# Altium schematic sheet Schematics.SchDoc
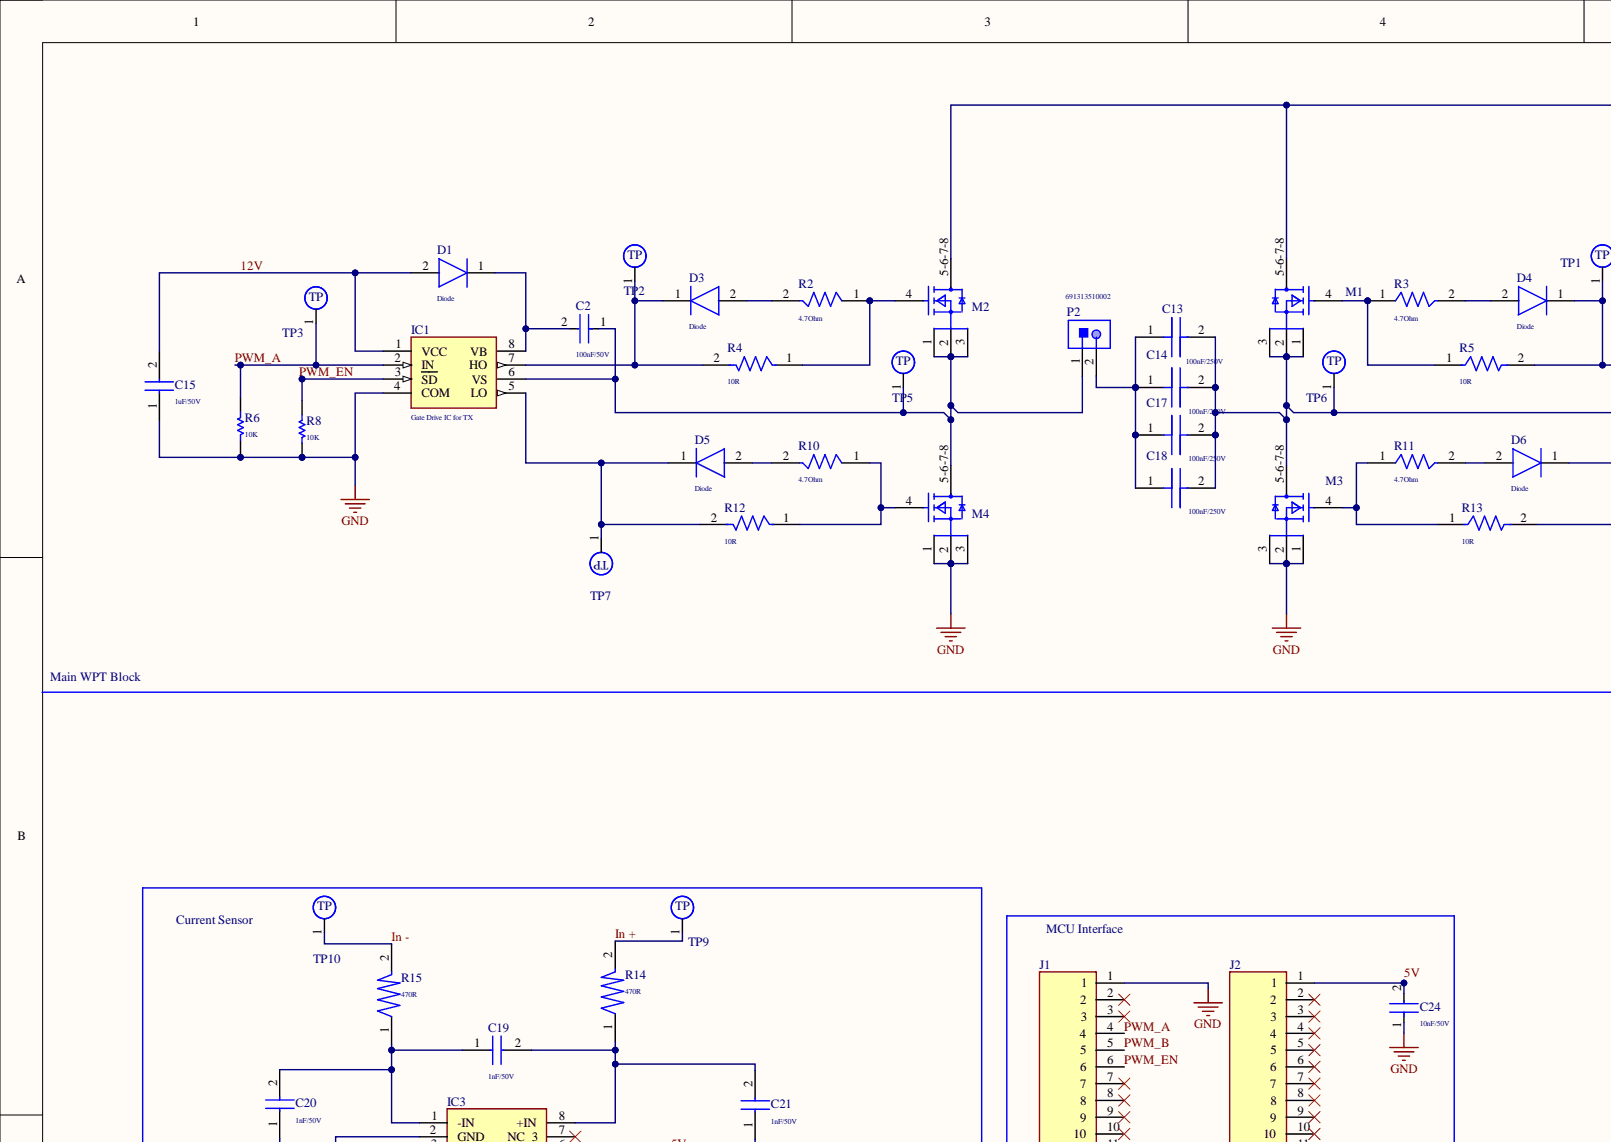
[diagram p0: Schematics.SchDoc, top left]
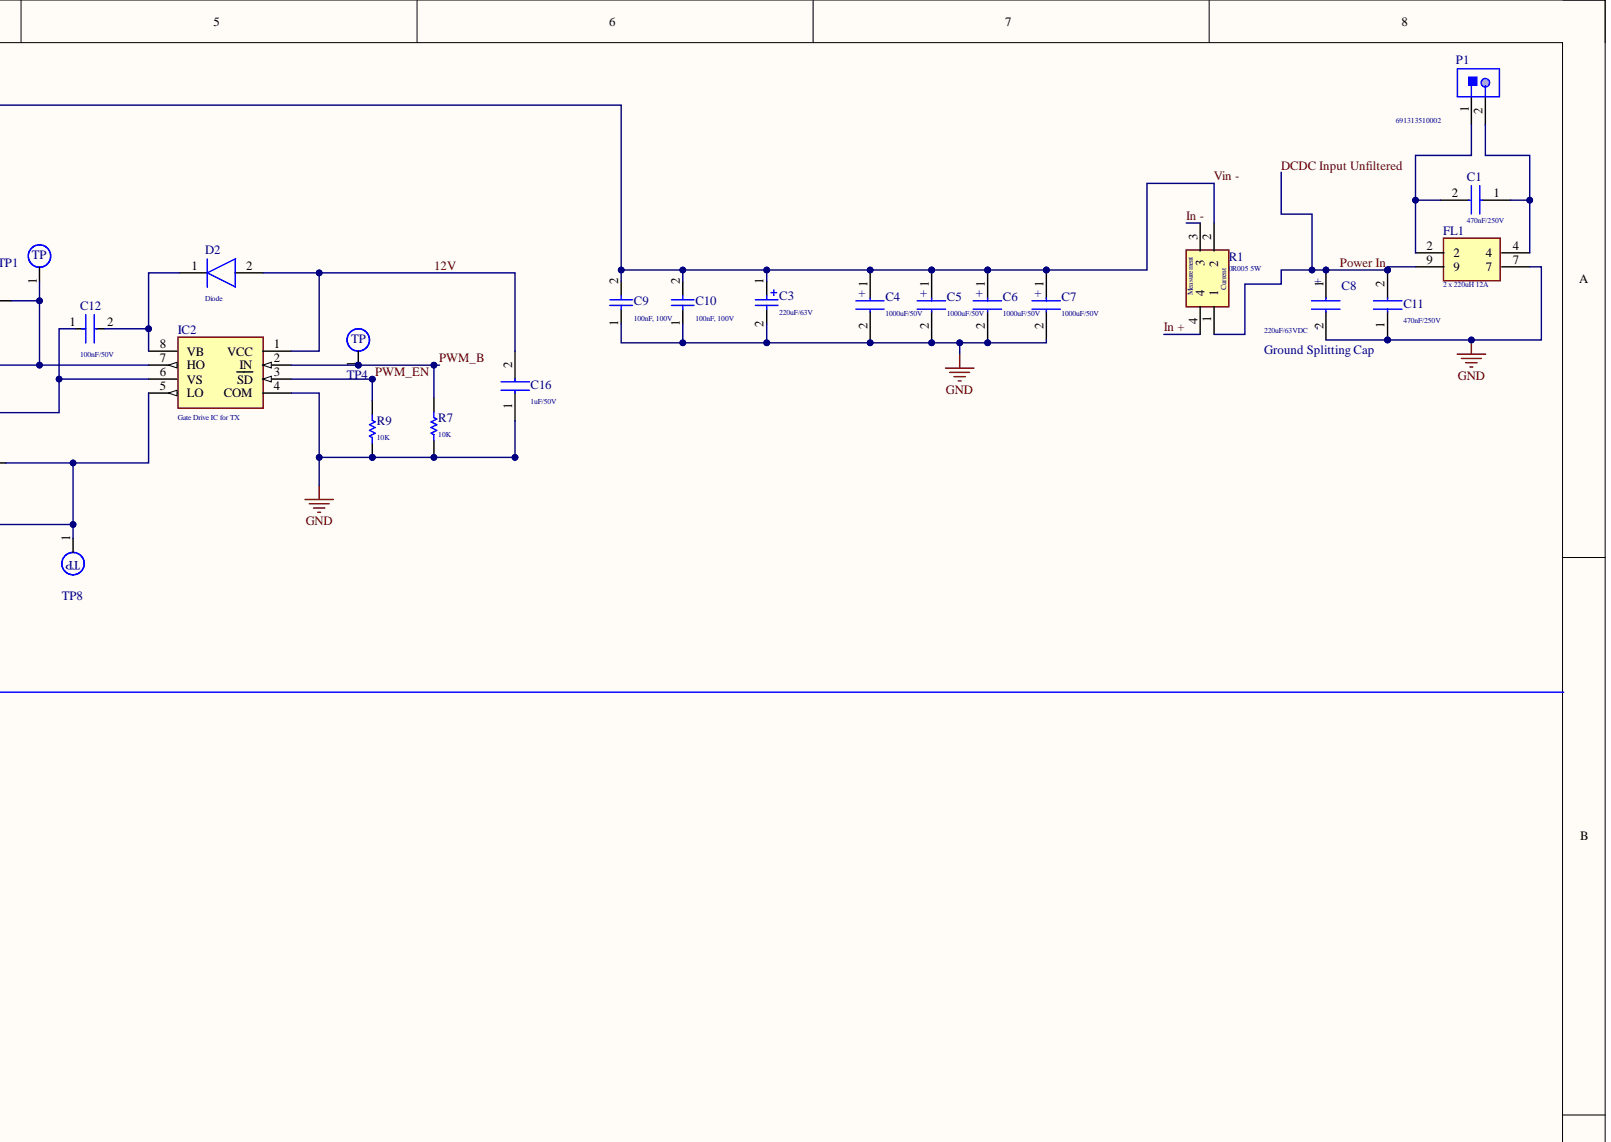
[diagram p1: Schematics.SchDoc, top right]
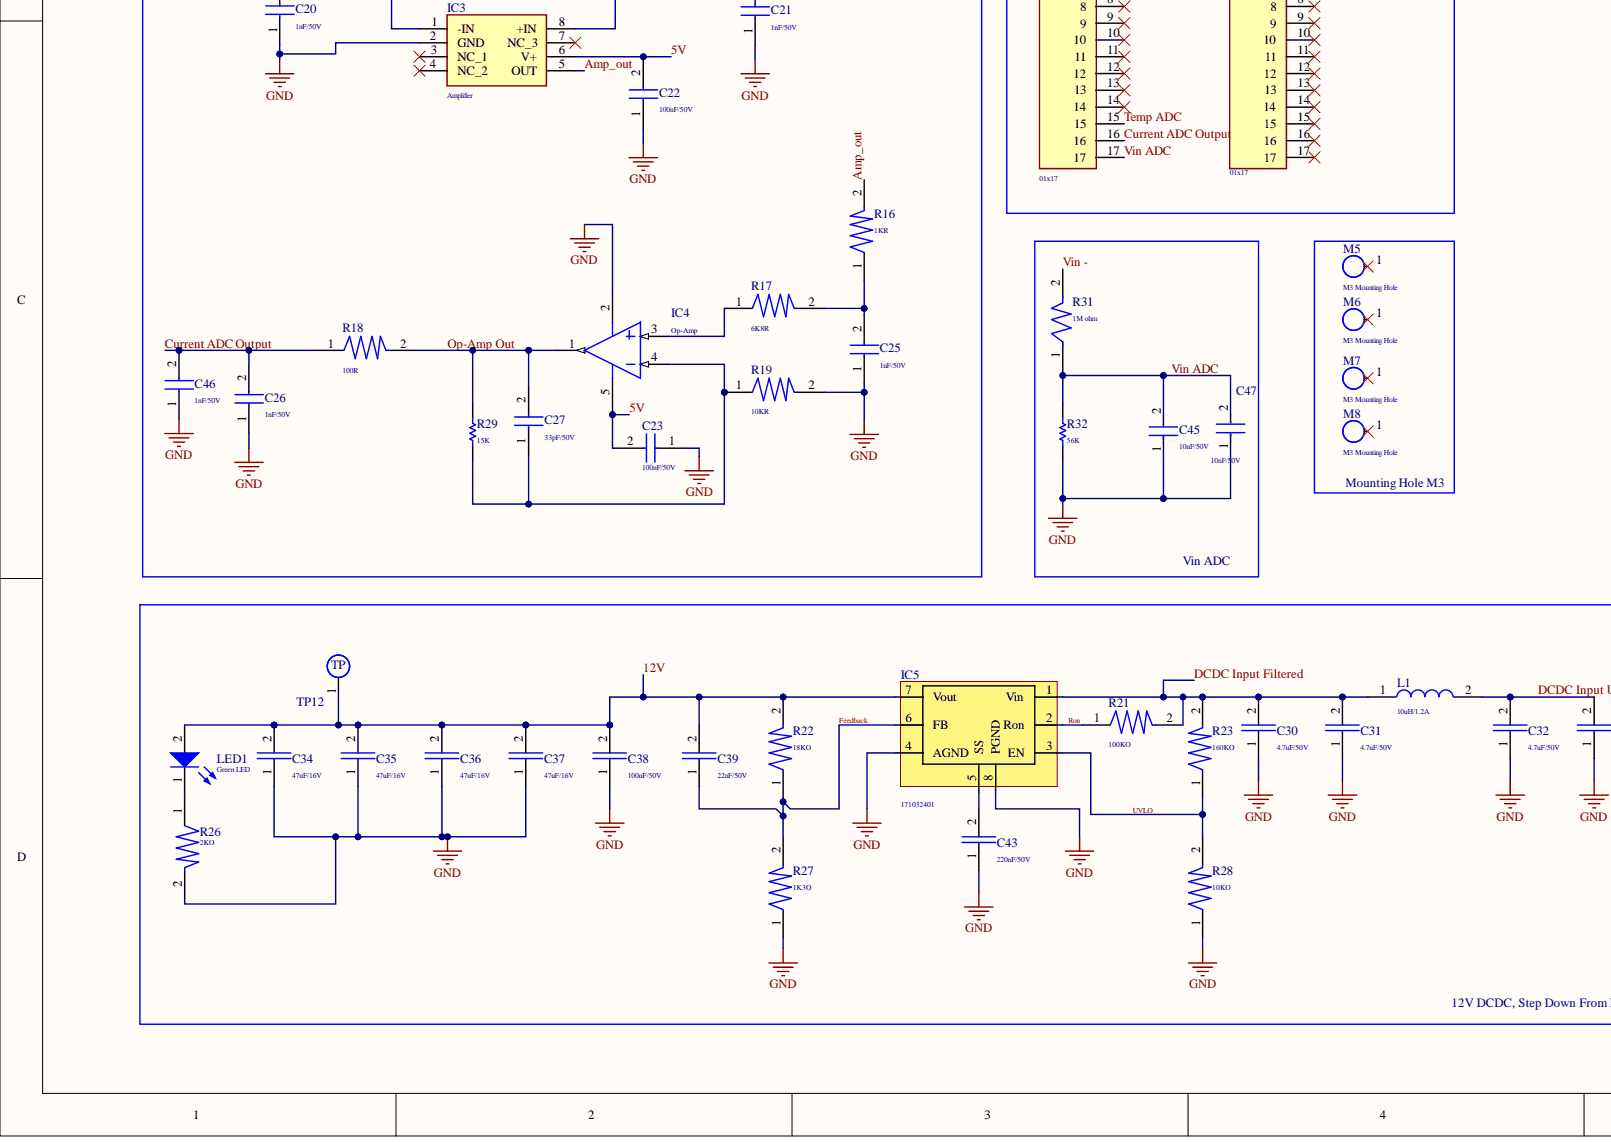
[diagram p2: Schematics.SchDoc, bottom left]
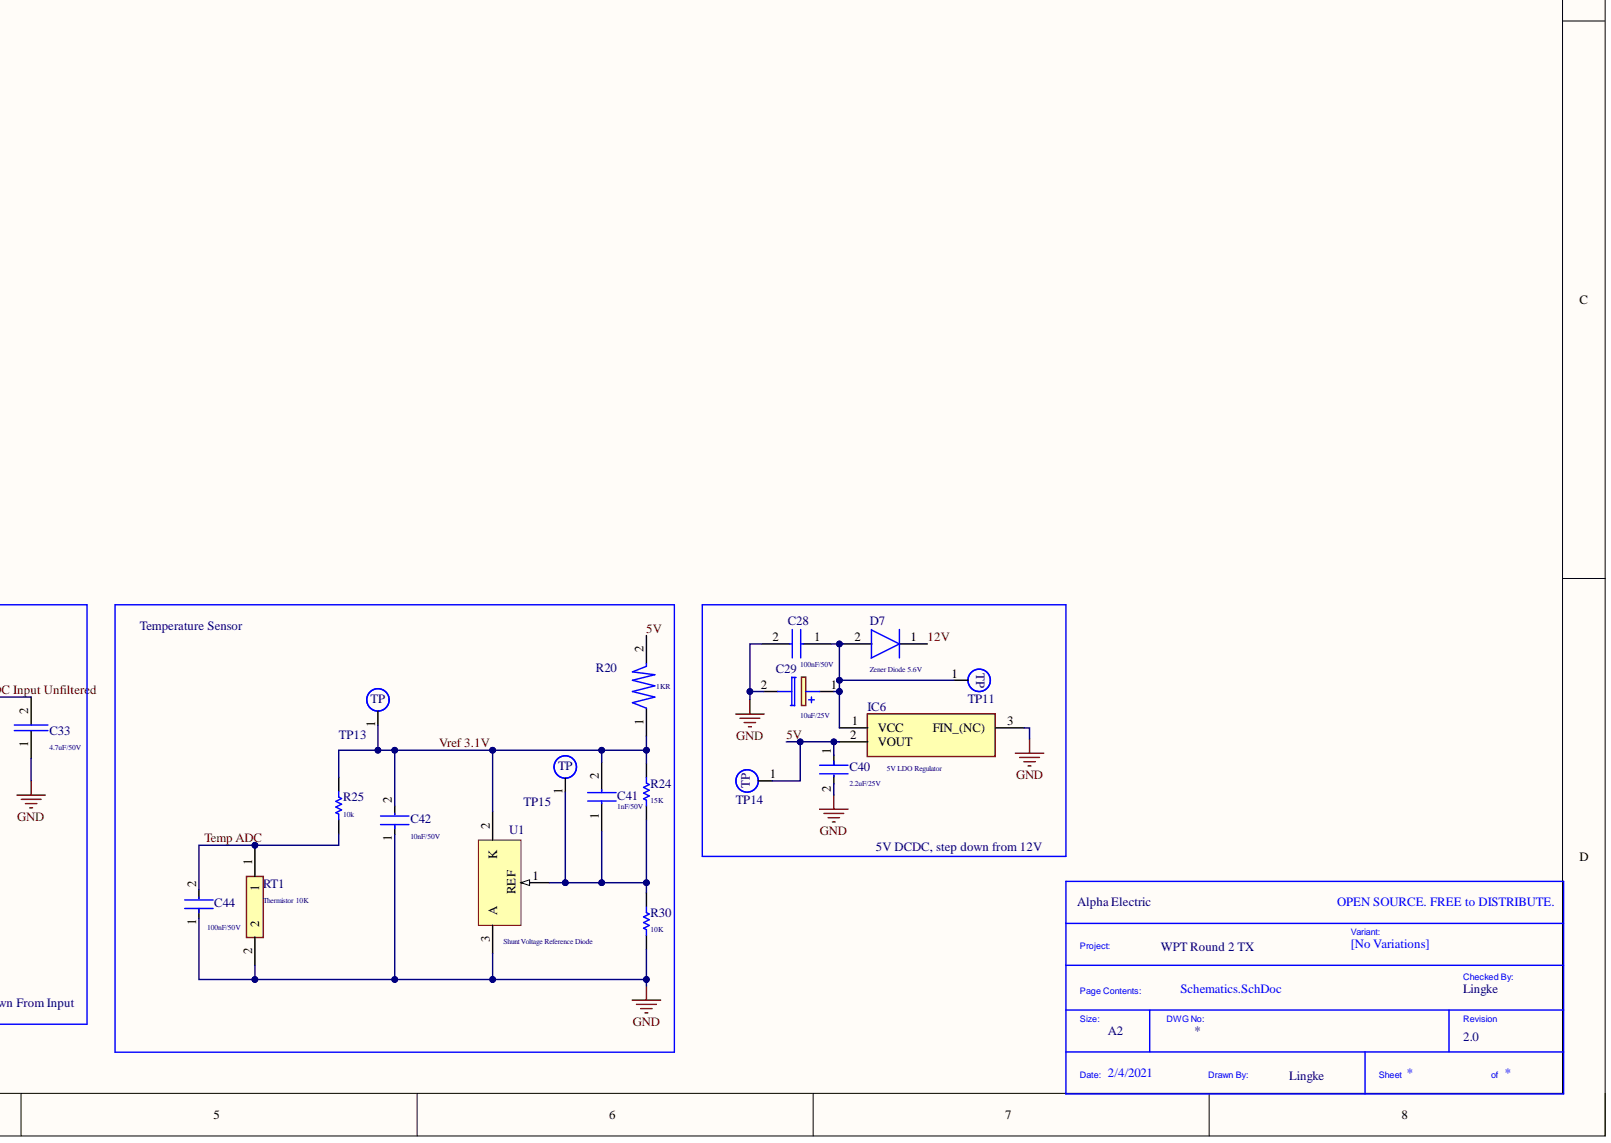
[diagram p3: Schematics.SchDoc, bottom right]

## Parts
*  Checked By: Lingke  Company: Alpha Electric  Project Name: WPT Round 2 TX  Revision: 2.0  Size: A2  (hidden comment: Title_Block)  (no BOM)
C1  470nF/250V  [890324025039CS]
C2  100nF/50V  [0805 Capacitor]
C3  220uF/63V  [WCAP-ATUL_D10H16]
C4  1000uF/50V  [CAPPRD500W62D1300H2650]
C5  1000uF/50V  [CAPPRD500W62D1300H2650]
C6  1000uF/50V  [CAPPRD500W62D1300H2650]
C7  1000uF/50V  [CAPPRD500W62D1300H2650]
C8  220uF/63VDC  [CAPPRD500W62D1000H2650]
C9  100nF, 100V  [WCAP-CSGP_0805_H=1.25mm]
C10  100nF, 100V  [WCAP-CSGP_0805_H=1.25mm]
C11  470nF/250V  [890324025039CS]
C12  100nF/50V  [0805 Capacitor]
C13  100nF/250V  [CAPC4532X220N]
C14  100nF/250V  [CAPC4532X220N]
C15  1uF/50V  [CAPC2012X135N]
C16  1uF/50V  [CAPC2012X135N]
C17  100nF/250V  [CAPC4532X220N]
C18  100nF/250V  [CAPC4532X220N]
C19  1nF/50V  [CAPC2012X90N]
C20  1nF/50V  [CAPC2012X90N]
C21  1nF/50V  [CAPC2012X90N]
C22  100nF/50V  [CAPC2012X90N]
C23  100nF/50V  [CAPC2012X90N]
C24  10nF/50V  [0805 Capacitor]
C25  1nF/50V  [CAPC2012X90N]
C26  1nF/50V  [CAPC2012X90N]
C27  33pF/50V  [CAPC2012X90N]
C28  100nF/50V  [CAPC2012X90N]
C29  10uF/25V  [CAPAE530X550N]
C30  4.7uF/50V  [CAPC3225X280N]
C31  4.7uF/50V  [CAPC3225X280N]
C32  4.7uF/50V  [CAPC3225X280N]
C33  4.7uF/50V  [CAPC3225X280N]
C34  47uF/16V  [CAPC3225X280N]
C35  47uF/16V  [CAPC3225X280N]
C36  47uF/16V  [CAPC3225X280N]
C37  47uF/16V  [CAPC3225X280N]
C38  100nF/50V  [CAPC3216X90N]
C39  22nF/50V  [CAPC2012X90N]
C40  2.2uF/25V  [0805 Capacitor]
C41  1nF/50V  [CAPC2012X90N]
C42  10nF/50V  [0805 Capacitor]
C43  220nF/50V  [CAPC2012X90N]
C44  100nF/50V  [0805 Capacitor]
C45  10nF/50V  [0805 Capacitor]
C46  1nF/50V  [CAPC2012X90N]
C47  10nF/50V  [0805 Capacitor]
D1  Diode  [SOD3716X135N]
D2  Diode  [SOD3716X135N]
D3  Diode  [SOD3716X135N]
D4  Diode  [SOD3716X135N]
D5  Diode  [SOD3716X135N]
D6  Diode  [SOD3716X135N]
D7  Zener Diode 5.6V  [ZMM5232B]
FL1  2 x 220uH 12A  [744844221]
IC1  Gate Drive IC for TX  [SOIC127P600X175-8N]
IC2  Gate Drive IC for TX  [SOIC127P600X175-8N]
IC3  Amplifier  [SOIC127P600X175-8N]
IC4  Op-Amp  [ADI-RJ-5_N]
IC5  171032401  [7 PIN PFM]
IC6  5V LDO Regulator  [ROHM_TO-252-3]
J1  01x17  [RHDR17W76P0X254_1X17_4318X254X826P]
J2  01x17  [RHDR17W76P0X254_1X17_4318X254X826P]
L1  10uH/1.2A  [WE-MAPI_3020]
LED1  Green LED  Color: Green  [0805_A]
M1  Mosfet  [FP-PG-TDSON-8-MFG]  (value not drawn)
M2  Mosfet  [FP-PG-TDSON-8-MFG]  (value not drawn)
M3  Mosfet  [FP-PG-TDSON-8-MFG]  (value not drawn)
M4  Mosfet  [FP-PG-TDSON-8-MFG]  (value not drawn)
M5  M3 Mounting Hole  [M3 Hole]
M6  M3 Mounting Hole  [M3 Hole]
M7  M3 Mounting Hole  [M3 Hole]
M8  M3 Mounting Hole  [M3 Hole]
P1  691313510002  [691313510002]
P2  691313510002  [691313510002]
R1  0R005 5W  [FC4L110R005FER]
R2  4.7Ohm  [FP-CRCW1206-e3-IPC_A]
R3  4.7Ohm  [FP-CRCW1206-e3-IPC_A]
R4  10R  [FP-CRCW1206-e3-IPC_A]
R5  10R  [FP-CRCW1206-e3-IPC_A]
R6  10K  [0805 Resistor]
R7  10K  [0805 Resistor]
R8  10K  [0805 Resistor]
R9  10K  [0805 Resistor]
R10  4.7Ohm  [FP-CRCW1206-e3-IPC_A]
R11  4.7Ohm  [FP-CRCW1206-e3-IPC_A]
R12  10R  [FP-CRCW1206-e3-IPC_A]
R13  10R  [FP-CRCW1206-e3-IPC_A]
R14  470R  [FP-CRCW0805-e3-MFG]
R15  470R  [FP-CRCW0805-e3-MFG]
R16  1KR  [FP-CRCW0805-e3-MFG]
R17  6K8R  [FP-CRCW0805-e3-MFG]
R18  100R  [FP-CRCW0805-e3-MFG]
R19  10KR  [FP-CRCW0805-e3-MFG]
R20  1KR  [FP-CRCW0805-e3-MFG]
R21  100KO  [FP-CRCW0805-e3-MFG]
R22  18KO  [FP-CRCW0805-e3-MFG]
R23  160KO  [FP-CRCW0805-e3-MFG]
R24  15K  [0805 Resistor]
R25  10k  [0805 Resistor]
R26  2KO  [FP-CRCW0805-e3-MFG]
R27  1K3O  [FP-CRCW0805-e3-MFG]
R28  10KO  [FP-CRCW0805-e3-MFG]
R29  15K  [0805 Resistor]
R30  10K  [0805 Resistor]
R31  1M ohm  [FP-CRCW0805-e3-MFG]
R32  56K  [0805 Resistor]
RT1  Thermistor 10K  [THRMC2012X95N]
TP1  TP  [Test Point]  (no BOM)
TP2  TP  [Test Point]  (no BOM)
TP3  TP  [Test Point]  (no BOM)
TP4  TP  [Test Point]  (no BOM)
TP5  TP  [Test Point]  (no BOM)
TP6  TP  [Test Point]  (no BOM)
TP7  TP  [Test Point]  (no BOM)
TP8  TP  [Test Point]  (no BOM)
TP9  TP  [Test Point]  (no BOM)
TP10  TP  [Test Point]  (no BOM)
TP11  TP  [Test Point]  (no BOM)
TP12  TP  [Test Point]  (no BOM)
TP13  TP  [Test Point]  (no BOM)
TP14  TP  [Test Point]  (no BOM)
TP15  TP  [Test Point]  (no BOM)
U1  Shunt Voltage Reference Diode  [SOT23-3_N]

## Nets
GND: C3.2 C4.2 C5.2 C6.2 C7.2 C8.2 C9.1 C10.1 C11.1 C15.1 C16.1 C20.1 C21.1 C22.1 C23.1 C24.1 C25.1 C26.1 C28.2 C29.2 C30.1 C31.1 C32.1 C33.1 C34.1 C35.1 C36.1 C37.1 C38.1 C40.2 C42.1 C43.1 C44.1 C45.1 C46.1 C47.1 FL1.7 IC1.4(COM) IC2.4(COM) IC3.2(GND) IC4.2 IC5.4(AGND) IC5.8(PGND) IC6.3 J1.1 M3.1 M3.2 M3.3 M4.1 M4.2 M4.3 R6.1 R7.1 R8.1 R9.1 R19.2 R26.2 R27.1 R28.1 R30.1 R32.1 RT1.2 U1.3
5V: C22.2 C23.2 C24.2 C40.1 IC3.6(V+) IC4.5 IC6.2 J2.1 R20.2 TP14.1
12V: C15.2 C16.2 C34.2 C35.2 C36.2 C37.2 C38.2 C39.2 D1.2 D2.2 D7.1 IC1.1(VCC) IC2.1(VCC) IC5.7(Vout) LED1.2 R22.2 TP12.1
Amp_out: IC3.5(OUT) R16.2
Current ADC Output: C26.2 C46.2 J1.16 R18.1
DCDC Input Filtered: C30.2 C31.2 IC5.1(Vin) L1.1 R21.2 R23.2
DCDC Input Unfiltered: C8.1 C11.2 C32.2 C33.2 FL1.9 L1.2 R1.1
Feedback: C39.1 IC5.6(FB) R22.1 R27.2
In +: R1.4 R14.2 TP9.1
In -: R1.3 R15.2 TP10.1
Op-Amp Out: C27.2 IC4.1 R18.2 R29.2
PWM_A: IC1.2(IN) J1.4 R6.2 TP3.1
PWM_B: IC2.2(IN) J1.5 R7.2 TP4.1
PWM_EN: IC1.3(SD) IC2.3(SD) J1.6 R8.2 R9.2
Ron: IC5.2(Ron) R21.1
Temp ADC: C44.2 J1.15 R25.1 RT1.1
UVLO: IC5.3(EN) R23.1 R28.2
Vin -: C3.1 C4.1 C5.1 C6.1 C7.1 C9.2 C10.2 M1.5-6-7-8 M2.5-6-7-8 R1.2 R31.2
Vin ADC: C45.2 C47.2 J1.17 R31.1 R32.2
Vref 3.1V: C41.2 C42.2 R20.1 R24.2 R25.2 TP13.1 U1.2
NetC1_1: C1.1 FL1.4 P1.2
NetC1_2: C1.2 FL1.2 P1.1
NetC2_1: C2.1 IC1.6(VS) M2.1 M2.2 M2.3 M4.5-6-7-8 P2.1 TP5.1
NetC2_2: C2.2 D1.1 IC1.8(VB)
NetC12_1: C12.1 C13.2 C14.2 C17.2 C18.2 IC2.6(VS) M1.1 M1.2 M1.3 M3.5-6-7-8 TP6.1
NetC12_2: C12.2 D2.1 IC2.8(VB)
NetC13_1: C13.1 C14.1 C17.1 C18.1 P2.2
NetC19_1: C19.1 C20.2 IC3.1(-IN) R15.1
NetC19_2: C19.2 C21.2 IC3.8(+IN) R14.1
NetC25_2: C25.2 R16.1 R17.2
NetC27_1: C27.1 IC4.4 R19.1 R29.1
NetC28_1: C28.1 C29.1 D7.2 IC6.1 TP11.1
NetC41_1: C41.1 R24.1 R30.2 TP15.1 U1.1
NetC43_2: C43.2 IC5.5(SS)
NetD3_1: D3.1 IC1.7(HO) R4.2 TP2.1
NetD3_2: D3.2 R2.2
NetD4_1: D4.1 IC2.7(HO) R5.2 TP1.1
NetD4_2: D4.2 R3.2
NetD5_1: D5.1 IC1.5(LO) R12.2 TP7.1
NetD5_2: D5.2 R10.2
NetD6_1: D6.1 IC2.5(LO) R13.2 TP8.1
NetD6_2: D6.2 R11.2
NetIC4_3: IC4.3 R17.1
NetLED1_1: LED1.1 R26.1
NetM1_4: M1.4 R3.1 R5.1
NetM2_4: M2.4 R2.1 R4.1
NetM3_4: M3.4 R11.1 R13.1
NetM4_4: M4.4 R10.1 R12.1

Not connected: IC3 3(NC_1) 4(NC_2) 7(NC_3); J1 2 3 7 8 9 10 11 12 13 14; J2 2 3 4 5 6 7 8 9 10 11 12 13 14 15 16 17; M5 1; M6 1; M7 1; M8 1

## Library data of the parts
* = Title_Block: Standard Title Block.; Library Name: Symbol Library.SchLib; Drawn By: Lingke
C1 C11 = 890324025039CS: Safety Capacitor, 470nF/250V; Manufacturer_Name: Wurth Elektronik; Component Kind: Standard; Height: 14.5mm; Library Name: Symbol Library.SchLib; Manufacturer_Part_Number: 890324025039CS
C2 C12 C44 = 885012207098: Component Kind: Standard; Description: 100nF/50V; Library Name: Symbol Library.SchLib; Supplier 1: Mouser; Supplier Part Number 1: 710-885012207098
C3 = 860020775019: WCAP-ATUL Aluminum Electrolytic Capacitor, Radial, THT, D10xH16mm, 220uF/63V; Manufacturer: Wurth Elektronik; Manufacturer Part Number: 860040881014; Capacitance: 220uF; Capacitance Tolerance: +/-20%; Dissipation Factor: 9%; Endurance: 10000h; Leakage Current: 820uA; Rated Voltage: 63VDC; Ripple Current: 375mA; Technical Reference: ATDL410821M100DSPS1K000; Component Kind: Standard; ComponentLink1Description: Manufacturer; ComponentLink1URL: http://www.we-online.de; ComponentLink2Description: Datasheet; ComponentLink2URL: https://www.we-online.com/catalog/datasheet/860040881014.pdf; Diameter: 18mm; Height: 41mm; Library Name: Symbol Library.SchLib; Mounting Technology: THT; Operating Temperature: -40 to +105degC; Packaging: Ammo Pack; Published Date: October 2020; Supplier 1: Mouser; Supplier Part Number 1: 710-860020775019
C4-C7 = ESH108M050AL4AA: Capacitor 1000uF; Component Kind: Standard; Library Name: Symbol Library.SchLib; Supplier 1: Mouser; Supplier Part Number 1: 80-ESH108M050AL4AA
C8 = 860040775010: Polarised Cap, 220uF/63VDC; Manufacturer_Name: Wurth Elektronik; Component Kind: Standard; Datasheet Link: https://www.mouser.de/datasheet/2/445/860040775010-1725627.pdf; Height: 26.5mm; Library Name: Symbol Library.SchLib; Manufacturer_Part_Number: 860040775010
C9 C10 = 885012207128: WCAP-CSGP Multilayer Ceramic Chip Capacitor, General Purpose, size 0805, X7R, 100nF, 100V; Manufacturer: Wurth Elektronik; Capacitance Tolerance: +/-10%; Dissipation Factor: #NAME?; Isolation Resistance: >=1GOhm; Rated Voltage: 100VDC; Technical Reference: X7R0805104K100DFCT10000; Capacitance: 100nF; Ceramic Type: X7R; Component Kind: Standard; ComponentLink1Description: Manufacturer; ComponentLink1URL: http://www.we-online.de; ComponentLink2Description: Datasheet; ComponentLink2URL: https://www.we-online.com/catalog/datasheet/885012207128.pdf; Height: 1.25mm; Library Name: Symbol Library.SchLib; Manufacturer Part Number: 885012207128; Mounting Technology: SMT; Operating Temperature: -55 to +125degC; Packaging: Tape and Reel; Published Date: May 2020; Size: 0805
C13 C14 C17 C18 = C1812C104JAGACAUTO: Manufacturer_Name: Kemet; Component Kind: Standard; Datasheet Link: https://api.kemet.com/component-edge/download/datasheet/C1812C104JAGACAUTO.pdf; Description: 100nF/250V; Height: 2.2mm; Library Name: Symbol Library.SchLib; Manufacturer_Part_Number: C1812C104JAGACAUTO; Supplier 1: Mouser; Supplier Part Number 1: 80-C1812C104JAGAUTO
C15 C16 = 885012207103: Capacitor 1uF/50V; Manufacturer_Name: Wurth Elektronik; Component Kind: Standard; Datasheet Link: https://katalog.we-online.com/pbs/datasheet/885012207103.pdf; Height: 1.35mm; Library Name: Symbol Library.SchLib; Manufacturer_Part_Number: 885012207103; Supplier 1: Mouser; Supplier Part Number 1: 710-885012207103
C19-C21 C25 C26 C41 C46 = 885012207086: Manufacturer_Name: Wurth Elektronik; Component Kind: Standard; Datasheet Link: https://katalog.we-online.com/pbs/datasheet/885012207086.pdf; Description: 1nF/50V; Height: 0.9mm; Library Name: Symbol Library.SchLib; Manufacturer_Part_Number: 885012207086; Supplier 1: Mouser; Supplier Part Number 1: 710-885012207086
C22 C23 C28 = 885012207098: Manufacturer_Name: Wurth Elektronik; Component Kind: Standard; Description: 100nF/50V; Height: 0.9mm; Library Name: Symbol Library.SchLib; Supplier 1: Mouser; Supplier Part Number 1: 710-885012207098
C24 C42 C45 C47 = 885012207092: Component Kind: Standard; Description: 10nF/50V; Library Name: Symbol Library.SchLib; Supplier 1: Mouser; Supplier Part Number 1: 710-885012207092
C27 = C0805C330J5GACTU: Capacitor 33pF/50V; Manufacturer_Name: Kemet; Component Kind: Standard; Library Name: Symbol Library.SchLib; Manufacturer_Part_Number: C0805C330J5GACTU; Supplier 1: Mouser; Supplier Part Number 1: 80-C0805C330J5G
C29 = 865060440001: Capacitor Polarised 10uF/25V; Manufacturer_Name: Wurth Elektronik; Component Kind: Standard; Datasheet Link: http://katalog.we-online.com/pbs/datasheet/865060440001.pdf; Height: 5.5mm; Library Name: Symbol Library.SchLib; Manufacturer_Part_Number: 865060440001
C30-C33 = 885012209048: Capacitor 4.7uF/50V; Manufacturer_Name: Wurth Elektronik; Component Kind: Standard; Datasheet Link: https://katalog.we-online.com/pbs/datasheet/885012209048.pdf; Height: 2.8mm; Library Name: Symbol Library.SchLib; Manufacturer_Part_Number: 885012209048; Supplier 1: Mouser; Supplier Part Number 1: 710-885012209048
C34-C37 = EMK325ABJ476MMHP: Capacitor 47uF/16V; Component Kind: Standard; Library Name: Symbol Library.SchLib; Supplier 1: Mouser; Supplier Part Number 1: 963-EMK325ABJ476MMHP
C38 = 885012208087: Capacitor 100nF/50V; Manufacturer_Name: Wurth Elektronik; Component Kind: Standard; Datasheet Link: https://componentsearchengine.com//885012208087.pdf; Height: 0.9mm; Library Name: Symbol Library.SchLib; Manufacturer_Part_Number: 885012208087; Mouser2 Part Number: 710-885012208087; Mouser2 Price/Stock: https://www.mouser.com/Search/Refine.aspx?Keyword=710-885012208087; Supplier 1: Mouser; Supplier Part Number 1: 710-885012208087
C39 = 885012207094: Capacitor 22nF/50V; Manufacturer_Name: Wurth Elektronik; Component Kind: Standard; Datasheet Link: https://componentsearchengine.com//885012207094.pdf; Height: 0.9mm; Library Name: Symbol Library.SchLib; Manufacturer_Part_Number: 885012207094; Mouser2 Part Number: 710-885012207094; Mouser2 Price/Stock: https://www.mouser.com/Search/Refine.aspx?Keyword=710-885012207094; Supplier 1: Mouser; Supplier Part Number 1: 710-885012207094
C40 = 885012207079: Capacitance: 2.2; Dielectric: X5R; Voltage Rating (DC): 25V; Case Code (Imperial): 0805; Case Code (Metric): 2012; Case/Package: 0805; Component Kind: Standard; Description: 2.2uF/25V; Library Name: Symbol Library.SchLib; Max Operating Temperature: 125°C; Package Quantity: 2000; Supplier 1: Mouser; Supplier Part Number 1: 710-885012207079
C43 = 885012207100: Capacitor 220nF / 50V; Component Kind: Standard; Library Name: Symbol Library.SchLib; Supplier 1: Mouser; Supplier Part Number 1: 710-885012207100
D1-D6 = 1N4148W-E3-08: Manufacturer_Name: Vishay; Component Kind: Standard; Datasheet Link: https://componentsearchengine.com//1N4148W-E3-08.pdf; Description: Diode; Height: 1.35mm; Library Name: Symbol Library.SchLib; Manufacturer_Part_Number: 1N4148W-E3-08; Mouser2 Part Number: 78-1N4148W-E3-08; Mouser2 Price/Stock: https://www.mouser.com/Search/Refine.aspx?Keyword=78-1N4148W-E3-08; Supplier 1: Digi-Key; Supplier Part Number 1: 1N4148W-E3-08CT-ND
D7 = ZMM5232B: Zener Diode 5.6V 0.5W; Manufacturer_Name: Rectron; Component Kind: Standard; Datasheet Link: https://componentsearchengine.com/Datasheets/1/ZMM5232B.pdf; Height: 1.5mm; Library Name: Symbol Library.SchLib; Manufacturer_Part_Number: ZMM5232B; Supplier 1: Mouser; Supplier Part Number 1: 583-ZMM5232B
FL1 = 744844221: Common Mode Choke 220uH 12A; Manufacturer_Name: Wurth Elektronik; Component Kind: Standard; Height: 33mm; Library Name: Symbol Library.SchLib; Manufacturer_Part_Number: 744844221
IC1 IC2 = IRS2008SPBF: Gate Drive IC; Manufacturer_Name: Infineon; Component Kind: Standard; Height: 1.75mm; Library Name: Symbol Library.SchLib; Manufacturer_Part_Number: IRS2008SPBF; Supplier 1: Mouser; Supplier Part Number 1: 726-IRS2008SPBF
IC3 = AD8215YRZ: Integrated Circuit Amplifier; Manufacturer_Name: Analog Devices; Component Kind: Standard; Datasheet Link: http://www.analog.com/static/imported-files/data_sheets/AD8215.pdf; Height: 1.75mm; Library Name: Symbol Library.SchLib; Manufacturer_Part_Number: AD8215YRZ; Supplier 1: Mouser; Supplier Part Number 1: 584-AD8215YRZ
IC4 = AD8031ARTZ-REEL7: Single Channel RRIO Operational Amplifier, 80 MHz BW, 35 V/us SR, Industrial, 5-pin SOT23 (RJ-5), Reel; Generic Part Number: AD8031; Manufacturer: Analog Devices; Amplifiers Per Package: 1; Input Bias Current: 450nA; Input Offset Voltage: 1mV; Iq/Amp: 900uA; Slew Rate: 35V/us; Small Signal Bandwidth: 80MHz; V Span Max: 12V; V Span Min: 2.7V; Code_JEDEC: MO-178-AA; Component Kind: Standard; ComponentLink1Description: Manufacturer URL; ComponentLink1URL: http://www.analog.com/en/index.html; ComponentLink2Description: Datasheet; ComponentLink2URL: http://www.analog.com/static/imported-files/data_sheets/AD8031_8032.pdf; ComponentLink3Description: Package Specification; ComponentLink3URL: http://www.analog.com/static/imported-files/packages/PKG_PDF/SOT-23(RJ)/RJ_5.pdf; ComponentLink4Description: Reference Circuit; ComponentLink4URL: http://www.analog.com/en/all-operational-amplifiers-op-amps/operational-amplifiers-op-amps/ad8031/products/product.html#product-recommendations; DatasheetVersion: Rev. D; Library Name: Symbol Library.SchLib; Mounting Technology: Surface Mount; PackageDescription: 5-Pin Small Outline Transistor Package, Body 2.9 x 1.6 mm, Pitch 0.95 mm; PackageReference: RJ-5; PackageVersion: 121608-A; Packing: Reel; PartNumber: AD8031ARTZ-REEL7; RevisionNote: Reference Circuit URL fixed; Supplier 1: Mouser; Supplier Part Number 1: 584-AD8031ARTZ-R7
IC5 = 171032401: MagI³C-VDRM Variable Step Down Regulator Module WE-VDRM , Ui=6-42V; Manufacturer: Wuerth Elektronik; Ui: 6-42 V; Component Kind: Standard; ComponentLink1Description: Manufacturer URL; ComponentLink1URL: http://www.we-online.com; ComponentLink2Description: Datasheet; ComponentLink2URL: http://katalog-live.we-online.de/pm/datasheet/171032401.pdf; Library Name: Symbol Library.SchLib; PackageReference: 7 PIN PFM; PartNumber: 171032401; Supplier 1: Mouser; Supplier Part Number 1: 710-171032401
IC6 = BD450M5FP-CE2: Manufacturer_Name: ROHM Semiconductor; Component Kind: Standard; Datasheet Link: https://componentsearchengine.com//BD450M5FP-CE2.pdf; Description: 5V LDO Regulator; Library Name: Symbol Library.SchLib; Manufacturer_Part_Number: BD450M5FP-CE2; Mouser2 Part Number: 755-BD450M5FP-CE2; Mouser2 Price/Stock: https://www.mouser.com/Search/Refine.aspx?Keyword=755-BD450M5FP-CE2
J1 J2 = 929850-01-17-RA: Connector 2.54mm; Manufacturer_Name: 3M; Component Kind: Standard; Datasheet Link: http://multimedia.3m.com/mws/media/22500O/3mtm-boardmount-skt-100-100x-100strt-sldr-tails-ts0815.pdf; Height: 8.26mm; Library Name: Symbol Library.SchLib; Manufacturer_Part_Number: 929850-01-17-RA; Supplier 1: Mouser; Supplier Part Number 1: 517-929850-01-17-RA
L1 = 74438336100: Inductor; Manufacturer_Name: Wurth Elektronik; Component Kind: Standard; Datasheet Link: http://katalog.we-online.de/pbs/datasheet/74438336100.pdf; Library Name: Symbol Library.SchLib; Manufacturer_Part_Number: 74438336100; Supplier 1: Mouser; Supplier Part Number 1: 710-74438336100
LED1 = 150080GS75000: SMD mono-color Chip LED, WL-SMCW, Green; Manufacturer: Wuerth Elektronik; Component Kind: Standard; ComponentLink1Description: Manufacturer URL; ComponentLink1URL: http://www.we-online.com; ComponentLink2Description: Datasheet; ComponentLink2URL: http://katalog.we-online.com/led/datasheet/150080GS75000.pdf; Library Name: Symbol Library.SchLib; PackageReference: 0805_A; PartNumber: 150080GS75000; Supplier 1: Mouser; Supplier Part Number 1: 710-150080GS75000
M1-M4 = BSC040N10NS5ATMA1: MOSFET N-CH 100V 100A 8TDSON  Mosfet Switcher; Manufacturer: Infineon; Depletion Mode: False; Drain To Source Resistance: 3.4mΩ; Gate Charge At Vgs: 58nC @ 10V; Gate To Source Voltage: 20V; Input Capacitace At Vds: 4100pF @ 50V; Max Forward Diode Voltage: 1.1V; Max Junction Temp: +150°C; Number Of Channels: 1; Power Dissipation: 139W; Pulse Drain Current: 400A; Reverse Recovery Charge: 90nC; Reverse Recovery Time: 54ns; Rthja Max: 50K/W; Threshold Vgs Max: 3.8V; Threshold Vgs Min: 2.2V; Transconductance: 120S; Turn Off Delay Time: 32ns; Turn On Delay Time: 13ns; Typ Forward Diode Voltage: 0.9V; Automotive: No; Category: Trans; Component Kind: Standard; ComponentLink2Description: Datasheet; ComponentLink2URL: https://www.infineon.com/dgdl/Infineon-BSC040N10NS5-DS-v02_02-EN.pdf?fileId=5546d4624a0bf290014a0fbcee2e6b38; Continuous Drain Current: 100A; Device Class L1: Discrete Semiconductors; Device Class L2: Transistors; Device Class L3: MOSFETs; Digikey Description: MOSFET N-CH 100V 100A 8TDSON; Drain To Source Breakdown Voltage: 100V; Drain To Source Voltage: 100V; Footprint Url: https://www.infineon.com/dgdl/Infineon-PG-TDSON-8-7-FP-v01_00-EN.pdf?fileId=5546d46259d9a4bf015a1b6adadd1f0a; Height: 1.1mm; Lead Free: Yes; Library Name: Symbol Library.SchLib; Manufacturer Part Number: BSC040N10NS5ATMA1; Mouser Description: MOSFET N-Ch 100V 100A TDSON-8; Number Of N Channels: 1; Octopart: https://octopart.com/bsc040n10ns5atma1-infineon-52825711; Package: PG-TDSON-8; RoHS: TRUE; Supplier 1: Mouser; Supplier Part Number 1: 726-BSC040N10NS5ATMA; Temperature: -55°C to +150°C; Temperature Range High: +150°C; Temperature Range Low: -55°C
M5-M8 = M3_Mounting_hole: Component Kind: Standard; Library Name: Symbol Library.SchLib
P1 P2 = 691313510002: Serie 313 - 5.08mm Close Horizontal PCB Header WR-TBL, 2 pin; Manufacturer: Wurth Elektronik; Gender: Male; Pin Number: 2; Component Kind: Standard; Datasheet URL: http://katalog.we-online.de/em/datasheet/6913135100xx.pdf; Library Name: Symbol Library.SchLib; Manufacturer URL: http://www.we-online.de; Mounting Technology: THT; Operating Temperature: -40to105degC; Package Reference: 691313510002; Packaging: Box; Part Number: 691313510002; Pitch: 5.08mm; Supplier 1: Mouser; Supplier Part Number 1: 710-691313510002; Publisher: WurthElektronik
R1 = FC4L110R005FER: Resistor 0R005 5W; Manufacturer_Name: Ohmite; Component Kind: Standard; Height: 0.7mm; Library Name: Symbol Library.SchLib; Manufacturer_Part_Number: FC4L110R005FER; Supplier 1: Mouser; Supplier Part Number 1: 588-FC4L110R005FER
R2 R3 R10 R11 = CRCW12064R70FKEA: RES Thick Film, 4.7Ω, 1%, 0.25W, 100ppm/°C, 1206  MOSFET Gate Fast-off Resistor 4.7Ohm; Max Power Dissipation: 250mW; Resistance: 4.7R; Voltage Rating: 200V; Case Code (Imperial): 1206; Case Code (Metric): 3216; Case/Package: 1206; Component Kind: Standard; Composition: Thick Film; Contact Plating: Tin; Depth: 1.6mm; Features: Automotive AEC-Q200; Height: 0.024inch; Length: 3.2mm; Library Name: Symbol Library.SchLib; Max Operating Temperature: 155°C; Military Standard: Not; Min Operating Temperature: -55°C; Mount: Surface Mount; Number of Pins: 2; Number of Terminations: 2; Package Quantity: 10; Packaging: Tape and Reel; Power Rating: 250mW; Radiation Hardening: No; Supplier 1: Mouser; Supplier Part Number 1: 71-CRCW12064R70FKEA; Temperature Coefficient: 100ppm/°C; Tolerance: 1%; Weight: 0.000353oz; Width: 0.063inch
R4 R5 R12 R13 = CRCW120610R0FKEA: RES Thick Film, 10Ω, 1%, 0.25W, 100ppm/°C, 1206  MOSFET Gate Resistor 10Ohm; Max Power Dissipation: 250mW; Voltage Rating: 200V; Case Code (Imperial): 1206; Case Code (Metric): 3216; Case/Package: 1206; Component Kind: Standard; Composition: Thick Film; Contact Plating: Tin; Depth: 1.6mm; Features: Automotive AEC-Q200; Height: 0.55mm; Lead Free: Lead Free; Length: 3.2mm; Library Name: Symbol Library.SchLib; Max Operating Temperature: 155°C; Military Standard: Not; Min Operating Temperature: -55°C; Number of Pins: 2; Number of Terminations: 2; Package Quantity: 10; Packaging: Tape and Reel; Power Rating: 250mW; Radiation Hardening: No; Resistance: 10R; Supplier 1: Digi-Key; Supplier Part Number 1: 541-10.0FDKR-ND; Temperature Coefficient: 100ppm/°C; Termination: SMD/SMT; Tolerance: 1%; Voltage Rating (DC): 200V; Weight: 0.000353oz; Width: 0.063inch
R6-R9 R30 = CRCW080510K0FKEB: High Presicion 10K Ohm; Component Kind: Standard; Library Name: Symbol Library.SchLib; Supplier 1: Newark; Supplier Part Number 1: 26R4046
R14 R15 = CRCW0805470RFKEB: Resistor 470 Ohm; Component Kind: Standard; Library Name: Symbol Library.SchLib; Number of Terminations: 2; Supplier 1: Mouser; Supplier Part Number 1: 71-CRCW0805470RFKEB
R16 R20 = CRCW08051K00FKEA: Resistor 1k Ohm; Component Kind: Standard; Library Name: Symbol Library.SchLib; Number of Terminations: 2; Supplier 1: Mouser; Supplier Part Number 1: 71-CRCW0805-1.0K-E3
R17 = CRCW08056K80FKEA: Resistor 6.8K Ohm; Component Kind: Standard; Library Name: Symbol Library.SchLib; Number of Terminations: 2; Supplier 1: Mouser; Supplier Part Number 1: 71-CRCW0805-6.8K-E3
R18 = CRCW0805100RFKEB: Resistor 100 Ohm; Component Kind: Standard; Library Name: Symbol Library.SchLib; Number of Terminations: 2; Supplier 1: Mouser; Supplier Part Number 1: 71-CRCW0805100RFKEB
R19 = CRCW080510K0FKEA: Resistor 10K Ohm; Component Kind: Standard; Library Name: Symbol Library.SchLib; Number of Terminations: 2; Supplier 1: Mouser; Supplier Part Number 1: 71-CRCW0805-10K-E3
R21 = CRCW0805100KFKEB: Resistor 100K Ohm; Component Kind: Standard; Library Name: Symbol Library.SchLib; Number of Terminations: 2; Supplier 1: Mouser; Supplier Part Number 1: 71-CRCW0805100KFKEB
R22 = CRCW080518K0FKEA: Resistor 18K Ohm; Component Kind: Standard; Library Name: Symbol Library.SchLib; Number of Terminations: 2; Supplier 1: Mouser; Supplier Part Number 1: 71-CRCW080518K0FKEA
R23 = CR0805-FX-1603ELF: Resistor 160K Ohm; Component Kind: Standard; Library Name: Symbol Library.SchLib; Number of Terminations: 2; Supplier 1: Mouser; Supplier Part Number 1: 652-CR0805FX-1603ELF
R24 = ERA-6ARW153V: High Presicion 15K Ohm; Component Kind: Standard; Library Name: Symbol Library.SchLib; Supplier 1: Mouser; Supplier Part Number 1: 667-ERA-6ARW153V
R25 = ERA-6ARB103V: High Presition and High reliability 10K Ohm Resistor; Component Kind: Standard; Library Name: Symbol Library.SchLib; Supplier 1: Mouser; Supplier Part Number 1: 667-ERA-6ARB103V
R26 = CRCW08052K00FKEB: RES Thick Film, 2kΩ, 1%, 0.125W, 100ppm/°C, 0805; Max Power Dissipation: 125mW; Resistance: 2kR; Voltage Rating: 150V; Case Code (Imperial): 0805; Case Code (Metric): 2012; Case/Package: 0805; Component Kind: Standard; Composition: Thick Film; Contact Plating: Tin; Features: Automotive AEC-Q200; Height: 0.02inch; Lead Free: Lead Free; Length: 0.079inch; Library Name: Symbol Library.SchLib; Max Operating Temperature: 155°C; Min Operating Temperature: -55°C; Number of Pins: 2; Number of Terminations: 2; Package Quantity: 10000; Packaging: Tape and Reel; Power Rating: 125mW; Radiation Hardening: No; Supplier 1: Mouser; Supplier Part Number 1: 71-CRCW08052K00FKEB; Temperature Coefficient: 100ppm/°C; Termination: SMD/SMT; Tolerance: 1%; Voltage Rating (DC): 150V; Weight: 0.000194oz; Width: 0.049inch
R27 = CRCW08051K30FKEB: Resistor 1K3 Ohm; Component Kind: Standard; Library Name: Symbol Library.SchLib; Number of Terminations: 2; Supplier 1: Mouser; Supplier Part Number 1: 71-CRCW08051K30FKEB
R28 = CRCW080510K0FKEAC: Resistor 10K Ohm; Component Kind: Standard; Library Name: Symbol Library.SchLib; Number of Terminations: 2; Supplier 1: Mouser; Supplier Part Number 1: 71-CRCW0805-10K-E3
R29 = ERA-6AED153V: 15K Ohm Resistor; Component Kind: Standard; Library Name: Symbol Library.SchLib; Supplier 1: Mouser; Supplier Part Number 1: 667-ERA-6AED153V
R31 = CRCW08051M00FKEA: RES Thick Film, 1MΩ, 1%, 0.125W, 100ppm/°C, 0805  1M Ohm Resistor; Power Rating: 125mW; Resistance: 1MR; Voltage Rating: 150V; Case Code (Imperial): 0805; Case Code (Metric): 2012; Case/Package: 0805; Component Kind: Standard; Composition: Thick Film; Contact Plating: Tin; Depth: 1.25mm; Height: 0.45mm; Lead Free: Lead Free; Length: 2mm; Library Name: Symbol Library.SchLib; Max Operating Temperature: 155°C; Military Standard: Not; Min Operating Temperature: -55°C; Mount: Surface Mount; Number of Pins: 2; Package Quantity: 5000; Packaging: Tape and Reel; Radiation Hardening: No; Supplier 1: Mouser; Supplier Part Number 1: 71-CRCW08051M00FKEAH; Temperature Coefficient: 100ppm/°C; Termination: SMD/SMT; Tolerance: 1%; Voltage Rating (DC): 150V; Weight: 0.000194oz; Width: 1.25mm
R32 = ERJ-6ENF5622V: 56K Ohm Resistor; Component Kind: Standard; Library Name: Symbol Library.SchLib; Supplier 1: Mouser; Supplier Part Number 1: 667-ERJ-6ENF5622V
RT1 = NTCS0805E3103HMT: Thermistor 10Kohm B Parameter: 3950k; Manufacturer_Name: Vishay; Component Kind: Standard; Datasheet Link: http://www.vishay.com/docs/29044/ntcs0805e3t.pdf; Height: 0.95mm; Library Name: Symbol Library.SchLib; Manufacturer_Part_Number: NTCS0805E3103HMT; Supplier 1: Mouser; Supplier Part Number 1: 594-NTCS0805E3103HMT
TP1-TP15 = Test Point: Test Point 1mm by 1mm; Library Name: Symbol Library.SchLib
U1 = TS432AILT: Shunt voltage reference, adjustable, 1.24 to 10V, 0.5% precision, 3-Pin SOT23-3L, Tape and Reel; Component Kind: Standard; ComponentLink1Description: Manufacturer URL; ComponentLink1URL: http://www.st.com; ComponentLink2Description: Datasheet; ComponentLink2URL: http://www.st.com/internet/com/TECHNICAL_RESOURCES/TECHNICAL_LITERATURE/DATASHEET/CD00003202.pdf; DatasheetVersion: Apr-2008; Library Name: Symbol Library.SchLib; PackageDescription: 3-Pin SOT-23 Package 0.95 mm Pitch, 2.4 mm Lead Span; PackageReference: SOT23-3; PackageVersion: 2011; Supplier 1: Digi-Key; Supplier Part Number 1: 497-TS432AILTCT-ND
